# Altium schematic sheet 3A04xx_Oxocard_Mini_Science_USB_PWR.SchDoc
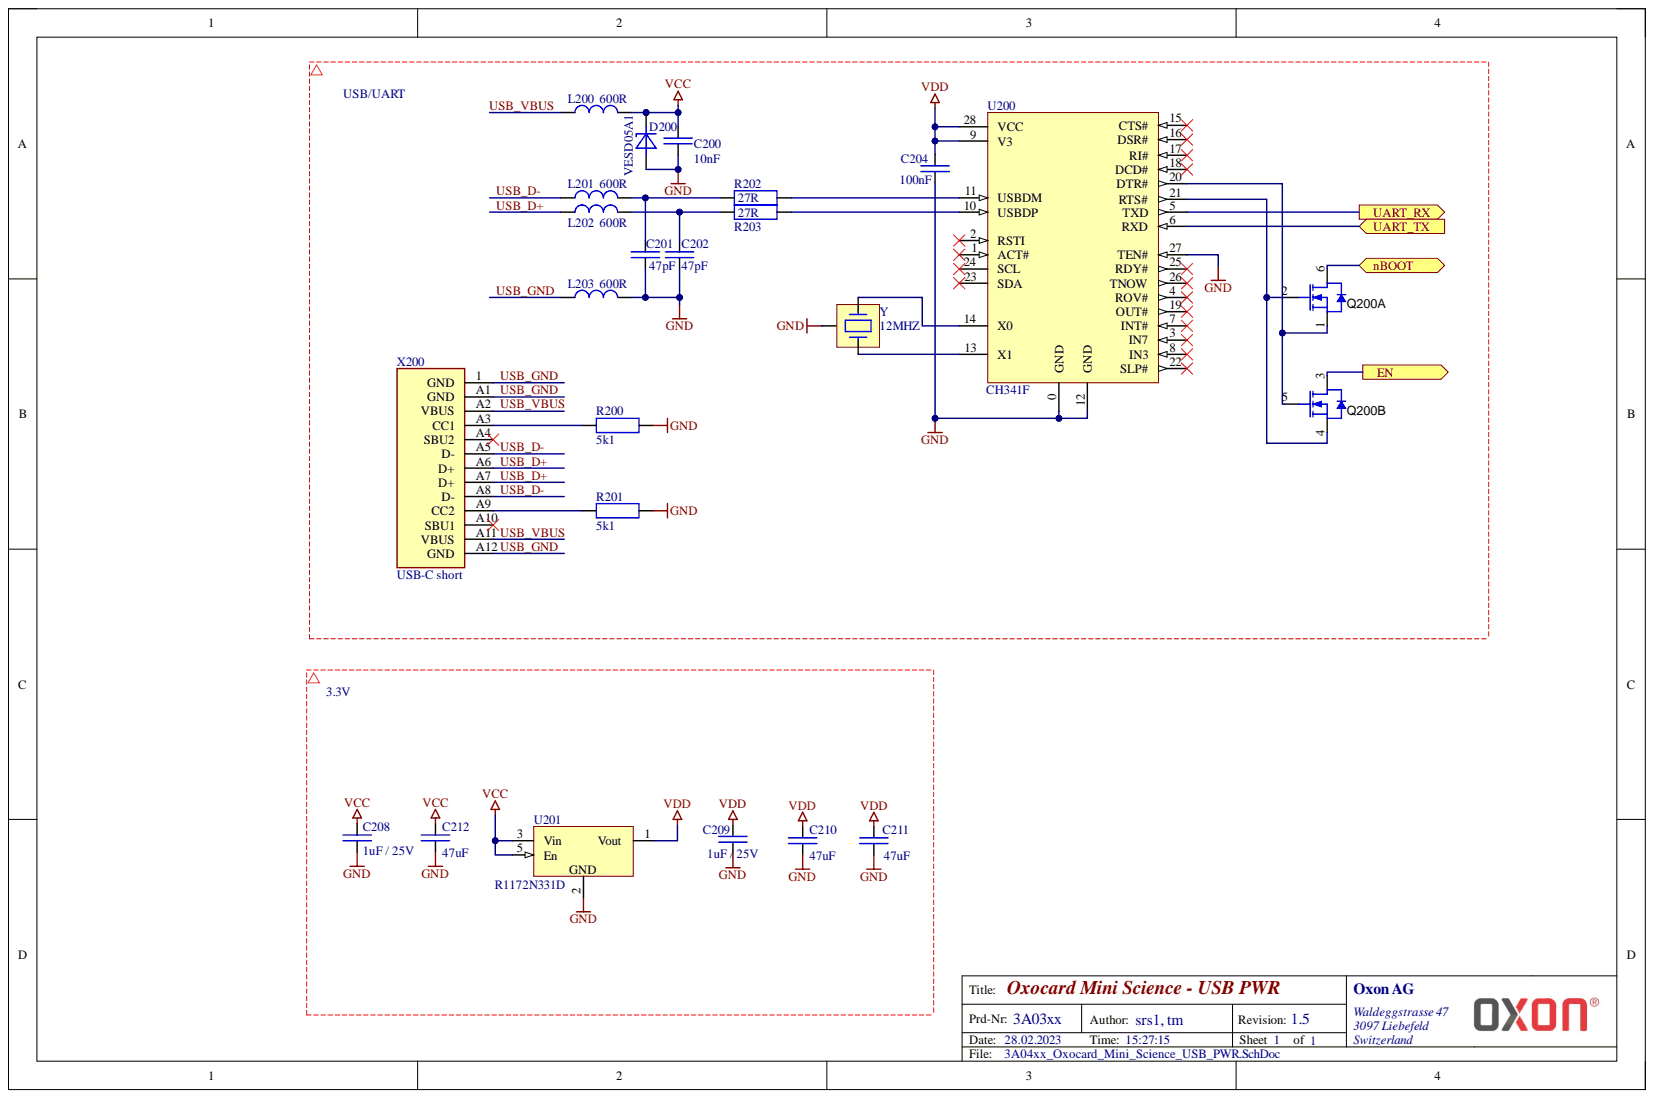
[diagram p0: 3A04xx_Oxocard_Mini_Science_USB_PWR.SchDoc]

## Parts
C200  10nF  [CAPC1005X60]
C201  47pF  [CAPC1005X60]
C202  47pF  [CAPC1005X60]
C204  100nF  [CAPC1005X60]
C208  1uF / 25V  [CAPC1005X60]
C209  1uF / 25V  [CAPC1005X60]
C210  47uF  [CAPC1608X80]
C211  47uF  [CAPC1608X80]
C212  47uF  [CAPC1608X80]
D200  VESD05A1  [SOD-523]
L200  600R  [INDC1005X60]
L201  600R  [INDC1005X60]
L202  600R  [INDC1005X60]
L203  600R  [INDC1005X60]
Q200  Q-N_MOSFET_2Channel  [SOTFL50P160X60-6N]  (value not drawn)  (parts A,B)
R200  5k1  [RESC1005X40]
R201  5k1  [RESC1005X40]
R202  27R  [RESC1005X40]
R203  27R  [RESC1005X40]
U200  CH341F  [QFN40P400X400X75_HS-29N]
U201  R1172N331D  [SOT23/5]
X200  USB-C short  [X-JAE_DX07S016JA1R1500]
Y  12MHZ  [QuarzCSTNE]

## Ports
EN, nBOOT, UART_RX, UART_TX

## Nets
GND: C200.1 C201.1 C202.1 C204.1 C208.1 C209.1 C210.1 C211.1 C212.1 D200.2 L203.2 R200.2 R201.2 U200.0(GND) U200.12(GND) U200.27(TEN#) U201.2 Y.2
VCC: C200.2 C208.2 C212.2 D200.1 L200.2 U201.3(Vin) U201.5(En)
VDD: C204.2 C209.2 C210.2 C211.2 U200.9(V3) U200.28(VCC) U201.1(Vout)
EN: Q200.3 ; port EN
nBOOT: Q200.6 ; port nBOOT
UART_RX: U200.5(TXD) ; port UART_RX
UART_TX: U200.6(RXD) ; port UART_TX
USB_D+: L202.1 X200.A6(D+) X200.A7(D+)
USB_D-: L201.1 X200.A5(D-) X200.A8(D-)
USB_GND: L203.1 X200.1(GND) X200.A1(GND) X200.A12(GND)
USB_VBUS: L200.1 X200.A2(VBUS) X200.A11(VBUS)
NetC201_2: C201.2 L201.2 R202.1
NetC202_2: C202.2 L202.2 R203.1
NetQ200_1: Q200.1 Q200.5 U200.20(DTR#)
NetQ200_2: Q200.2 Q200.4 U200.21(RTS#)
NetR200_1: R200.1 X200.A3(CC1)
NetR201_1: R201.1 X200.A9(CC2)
NetR202_2: R202.2 U200.11(USBDM)
NetR203_2: R203.2 U200.10(USBDP)
NetU200_13: U200.13(X1) Y.3
NetU200_14: U200.14(X0) Y.1

Not connected: U200 1(ACT#) 2(RSTI) 3(IN7) 4(ROV#) 7(INT#) 8(IN3) 15(CTS#) 16(DSR#) 17(RI#) 18(DCD#) 19(OUT#) 22(SLP#) 23(SDA) 24(SCL) 25(RDY#) 26(TNOW); X200 A4(SBU2) A10(SBU1)

## Library data of the parts
C200 = C-CAP0402_10n: SMD capacitor 25V 5%; Manufacturer: Yageo; Manufacturer Designator: GCM155R71E103JA7D; Component Kind: Standard; Distributor: Mouser; Distributor Part-Number: 81-GCM155R71E103JA7D; Library Name: XLib.SCHLIB
C201 C202 = C-CAP0402_47p: SMD capacitor 50V 2%; Manufacturer: Yageo; Manufacturer Designator: CC402GRNPO9BN470; Component Kind: Standard; Distributor: Mouser; Distributor Part-Number: 603-CC402GRNPO9BN470; Library Name: XLib.SCHLIB
C204 = C-CAP0402_100n: SMD capacitor 10V 5%; Manufacturer: Wurth Electronics; Manufacturer Designator: GRM155R61A104JA1D; Component Kind: Standard; Distributor: Mouser; Distributor Part-Number: 81-GRM155R61A104JA1D; Library Name: XLib.SCHLIB
C208 C209 = C-CAP0402_1u: SMD capacitor 25V 10%; Manufacturer: Wurth Electronics; Manufacturer Designator: GRM155R61E105KA12J; Component Kind: Standard; Distributor: Mouser; Distributor Part-Number: 81-GRM155R61E105KA12J; Library Name: XLib.SCHLIB
C210-C212 = C-CAP0603_47u: SMD capacitor 25V 10%; Manufacturer: Murata; Manufacturer Designator: GRM188R60J476ME5J; Component Kind: Standard; Distributor: Mouser; Distributor Part-Number: 81-GRM188R60J476ME5J; Library Name: XLib.SCHLIB
D200 = D-Transient Voltage Suppressor 5V, 16A: Transient Voltage Suppressor; Manufacturer: Vishay; Manufacturer Designator: VESD05A1-02V-G-08; Component Kind: Standard; Distributor: Mouser; Distributor Part-Number: 78-VESD05A1-02V-G-08; Library Name: XLib.SCHLIB
L200-L203 = L-Ind00402_Ferrit600R: SMD Ferrit 25%; Manufacturer: muRata; Manufacturer Designator: BLM15PX601SN1D; Component Kind: Standard; Distributor: Mouser; Distributor Part-Number: 81-BLM15PX601SN1D; Library Name: XLib.SCHLIB
Q200 = Q-N_MOSFET_2Channel: MOSFET, 2x N-CH ,20V, 800mA; Manufacturer: Nexperia; Manufacturer Designator: PMDT290UNE,115; Component Kind: Standard; Distributor: Mouser; Distributor Part-Number: 771-PMDT290UNE,115; Library Name: XLib.SCHLIB
R200 R201 = R-RES0402_5k1: SMD resistor 1%; Manufacturer: ROHM Semiconductor; Manufacturer Designator: SFR01MZPF5101; Component Kind: Standard; Distributor: Mouser; Distributor Part-Number: 755-SFR01MZPF5101; Library Name: XLib.SCHLIB
R202 R203 = R-RES0402_27R: SMD resistor 1%; Manufacturer: Yageo; Manufacturer Designator: RT0402FRE0727RL; Component Kind: Standard; Distributor: Mouser; Distributor Part-Number: 603-RT0402FRE0727RL; Library Name: XLib.SCHLIB
U200 = U-CH341F: USB 2.0 to UART; Manufacturer: WCH; Component Kind: Standard; Distributor: WCH; Distributor Part-Number: CH341F; Library Name: XLib.SCHLIB; Manufacturer Designator: CH341F
U201 = U-R1172N331D-TR-FE: LDO 3.3V 1A; Manufacturer: Ricoh Electronic Devices Company; Component Kind: Standard; Distributor: Mouser; Distributor Part-Number: 848-R1172N331D-TR-FE; Library Name: XLib.SCHLIB; Manufacturer Designator: R1172N331D-TR-FE
X200 = X-12-USB-C: USB C short; Manufacturer: JAE Electronics; Manufacturer Designator: DX07S016JA1R1500; Component Kind: Standard; Distributor: Mouser; Distributor Part-Number: 656-DX07S016JA1R1500; Library Name: XLib.SCHLIB
Y = Q-Quarz12MHZ: Crystal; Manufacturer: Murata; Manufacturer Designator: CSTNE12M0G55A000R0; Component Kind: Standard; Distributor: Mouser; Distributor Part-Number: 81-CSTNE12M0G55A000R; Library Name: XLib.SCHLIB
